# Altium schematic sheet LTE_auto_start_Rev01.SchDoc
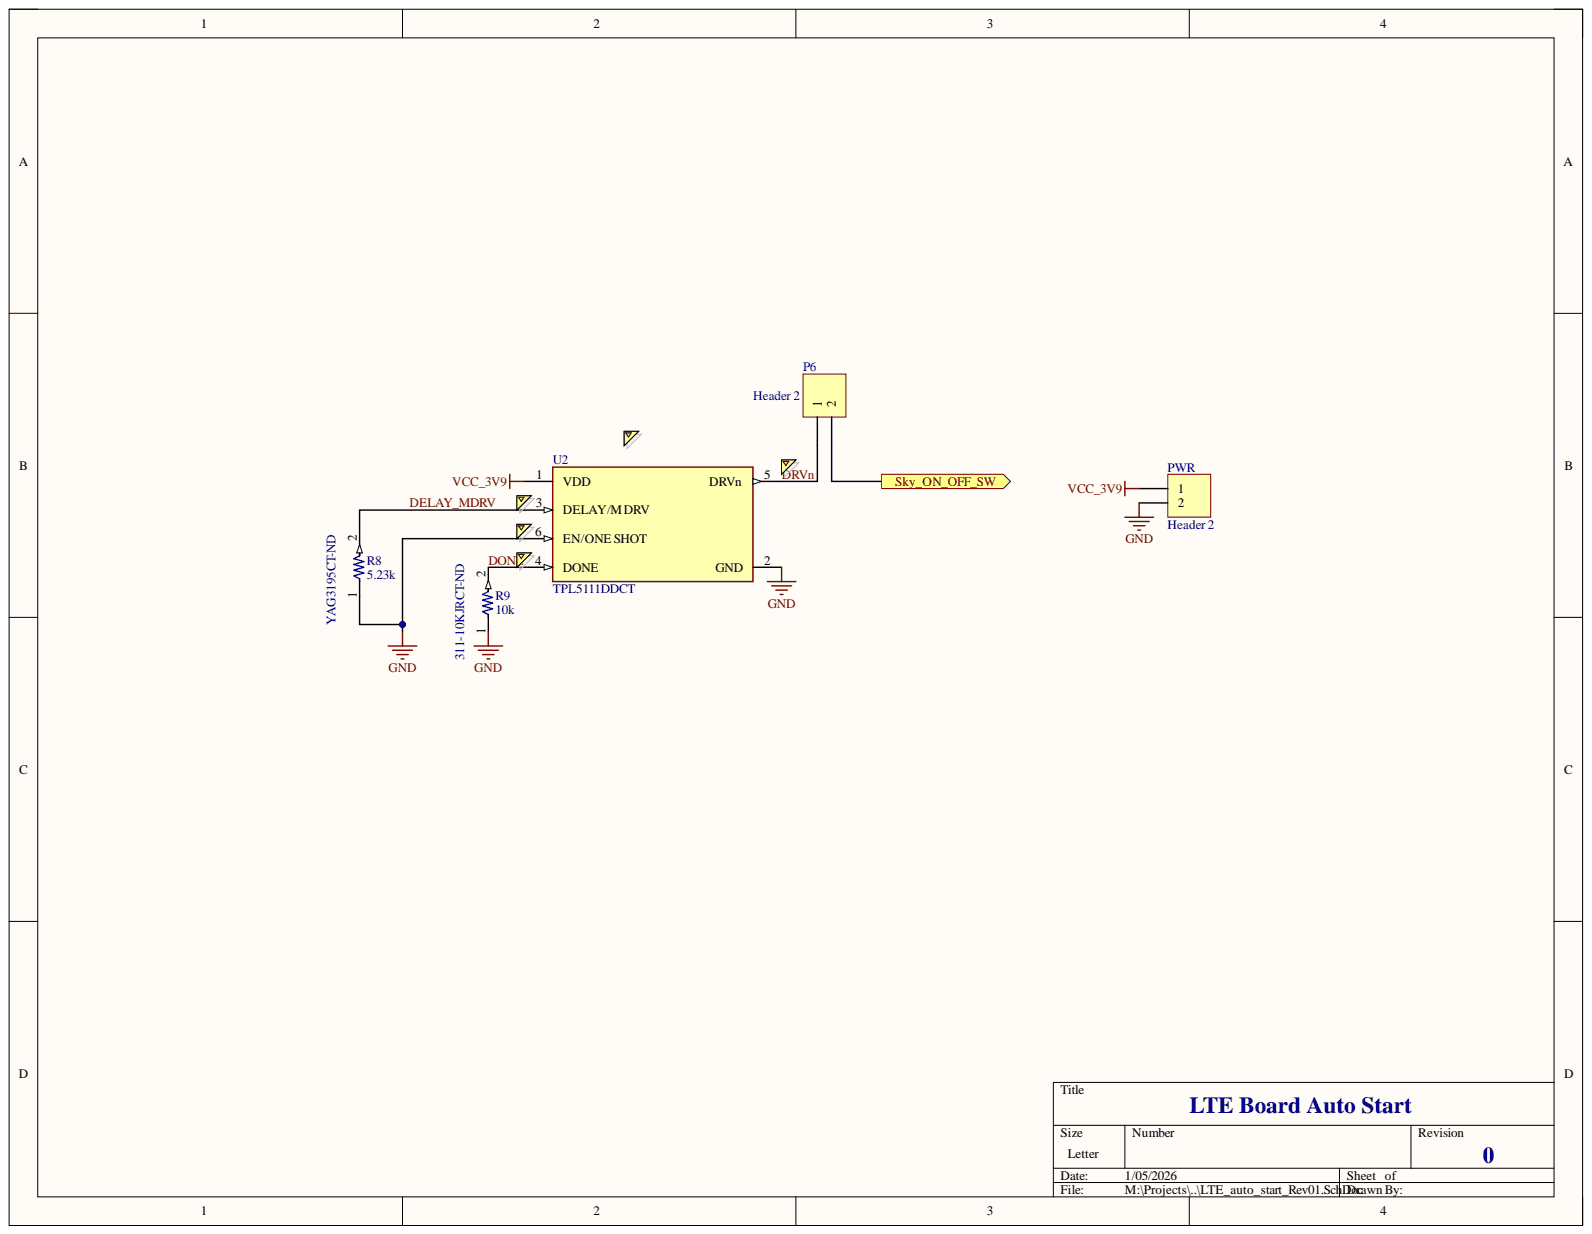
[diagram p0: LTE_auto_start_Rev01.SchDoc]

## Parts
P6  Header 2  [HDR1X2]
PWR  Header 2  [Wire_entry_200mil]
R8  YAG3195CT-ND  Resistance_Ohms: 5.23k  [SMD RES 1005]
R9  Digi-Key Part Number: 311-10KJRCT-ND  Resistance_Ohms: 10k  [SMD RES 1005]  (hidden comment: RC0402JR-0710KL)
U2  TPL5111DDCT  [FP-DDC0006A-IPC_B]

## Ports
Sky_ON_OFF_SW

## Nets
GND: PWR.2 R8.1 R9.1 U2.2(GND) U2.6(EN/ONE_SHOT)
VCC_3V9: PWR.1 U2.1(VDD)
DELAY_MDRV: R8.2 U2.3(DELAY/M_DRV)
DONE: R9.2 U2.4(DONE)
DRVn: P6.1 U2.5(DRVn)
Sky_ON_OFF_SW: P6.2 ; port Sky_ON_OFF_SW

## Library data of the parts
P6 PWR = Header 2: Header, 2-Pin; Component Kind: Standard; LatestRevisionDate: 17-Jul-2002; LatestRevisionNote: Re-released for DXP Platform.; Library Name: Miscellaneous Connectors.IntLib; Signal Integrity: Connector; Publisher: Altium Limited
R8 = 1000794: 5.23 kOhms ±1% 0.063W, 1/16W Chip Resistor 0402 (1005 Metric) Moisture Resistant Thick Film; Manufacturer: YAGEO; Manufacturer1: Bourns Inc; Manufacturer Product Number: RC0402FR-075K23L; Item_Phase: Production; Mounting_Type: Surface Mount; Number_of_Terminations: 2; Operating_Temperature: -55°C ~ 155°C; Resistance: 5.23k 1%; Temperature_Coefficient: ±100ppm/°C; Component Kind: Standard; Composition: Thick Film; Database Table Name: Resistor; Digi-Key Part Number: YAG3195CT-ND; Features: Moisture Resistant; Height: 0.016" (0.40mm); Library Name: AltiumProductionDatabase.DbLib; Part_Number: YAG3195CT-ND; Part_Type: Resistor; Power: 0.063W, 1/16W; Resistor_Package: 0402 (1005 Metric); Revision: A; Size_Dimension: 0.039" L x 0.020" W (1.00mm x 0.50mm); Tolerance: ±1%
R9 = 1000794: 10 kOhms ±5% 0.063W, 1/16W Chip Resistor 0402 (1005 Metric) Moisture Resistant Thick Film; Manufacturer: YAGEO; Manufacturer1: Bourns Inc; Item_Phase: Production; Mounting_Type: Surface Mount; Number_of_Terminations: 2; Operating_Temperature: -55°C ~ 155°C; Temperature_Coefficient: ±100ppm/°C; Tolerance: ±1%; Component Kind: Standard; Composition: Thick Film; Database Table Name: Resistor; Features: Moisture Resistant; Height: 0.016" (0.40mm); Library Name: AltiumProductionDatabase.DbLib; Manufacturer Product Number: RC0402JR-0710KL; Part_Number: 311-10KJRCT-ND; Part_Type: Resistor; Power: 0.063W, 1/16W; Resistor_Package: 0402 (1005 Metric); Revision: A; Size_Dimension: 0.039" L x 0.020" W (1.00mm x 0.50mm)
U2 = CMP-04857-000005-1: IC OSC PROG TIMER TSOT23-6; Manufacturer: Texas Instruments; Max Supply Voltage: 5.5V; Min Supply Voltage: 1.8V; Operating Supply Current: 35nA; Case/Package: SOT-23-6; Component Kind: Standard; ComponentLink1Description: Datasheet; Digi-Key Part Number: 296-42621-1-ND; Lead Free: Lead Free; Library Name: Altium Content Vault; Manufacturer Product Number: TPL5111DDCT; Max Operating Temperature: 105°C; Min Operating Temperature: -40°C; Mount: Surface Mount; Number of Pins: 6; Packaging: Cut Tape; Quiescent Current: 35nA; REACH SVHC: No SVHC; RoHS Compliant: Yes; Value: IC; ComponentLink1URL: C:\Users\Roman.Chak\Documents\Projects\UVDI-360\Docs\Datasheets\TI\tpl5111.pdf
